# Altium schematic sheet [05]-CT-Sensor.SchDoc
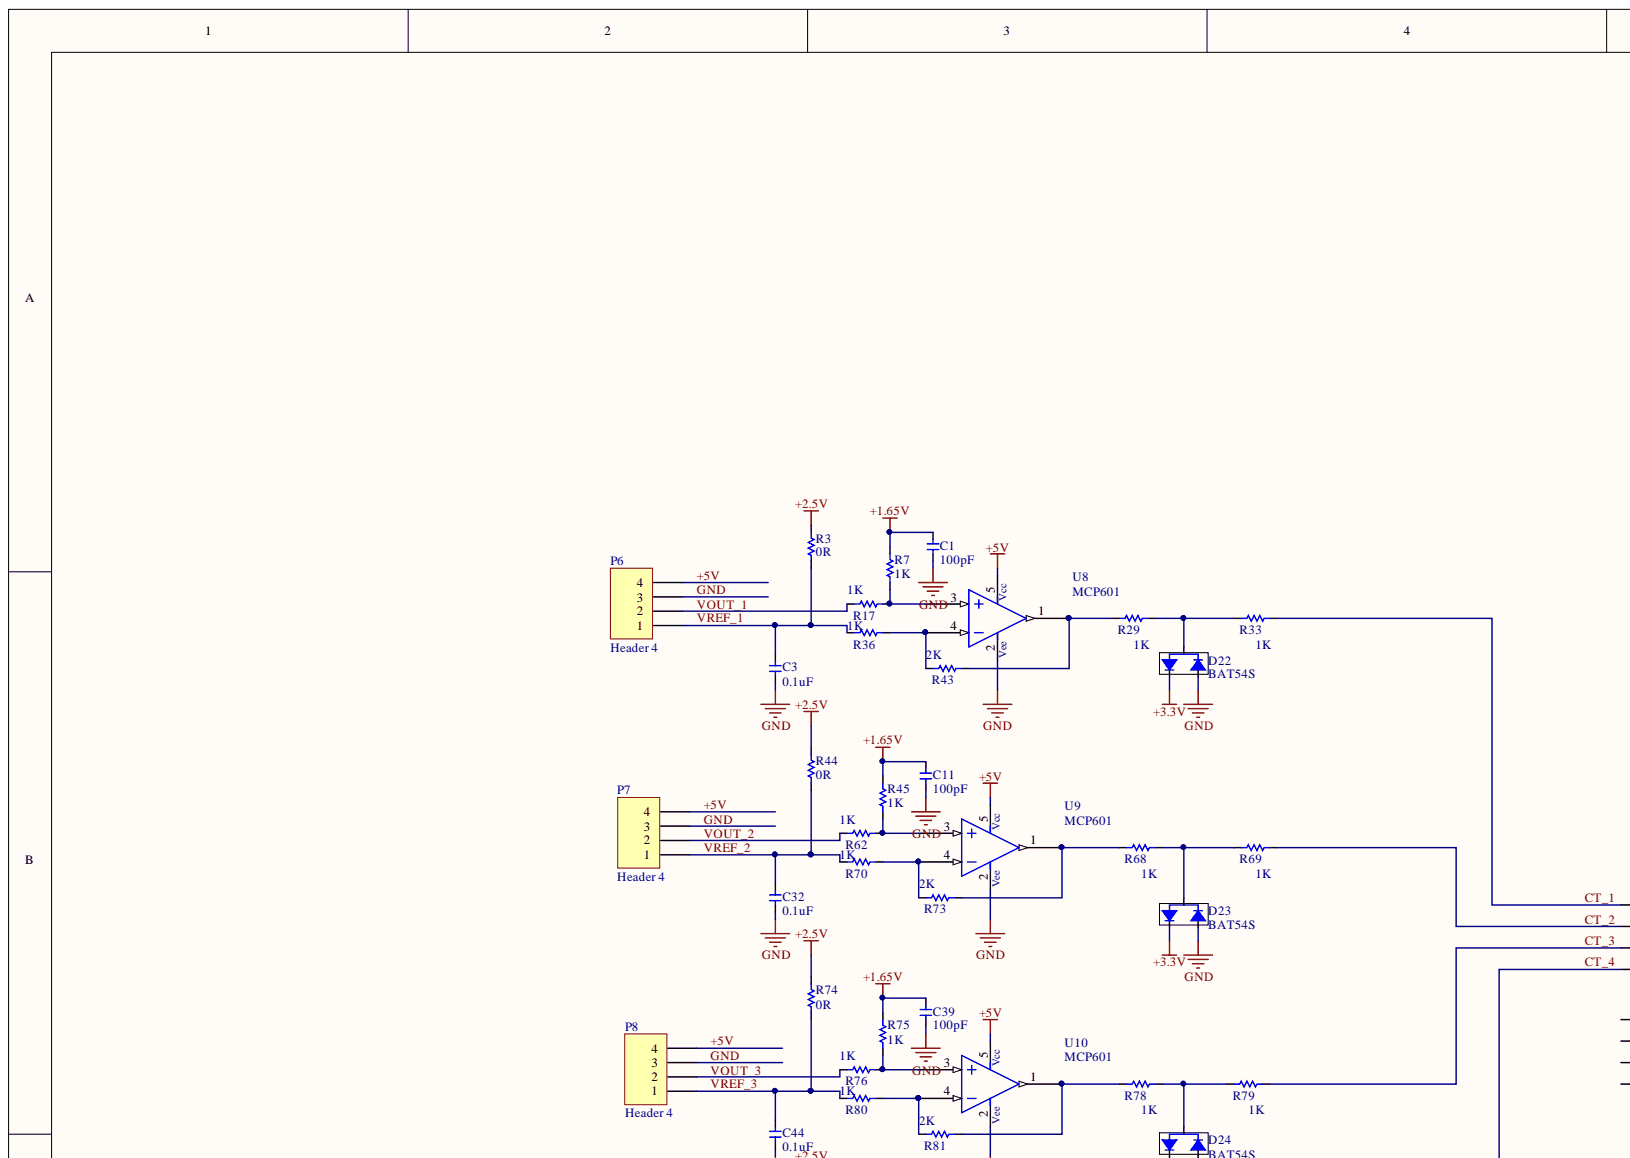
[diagram p0: [05]-CT-Sensor.SchDoc, top left]
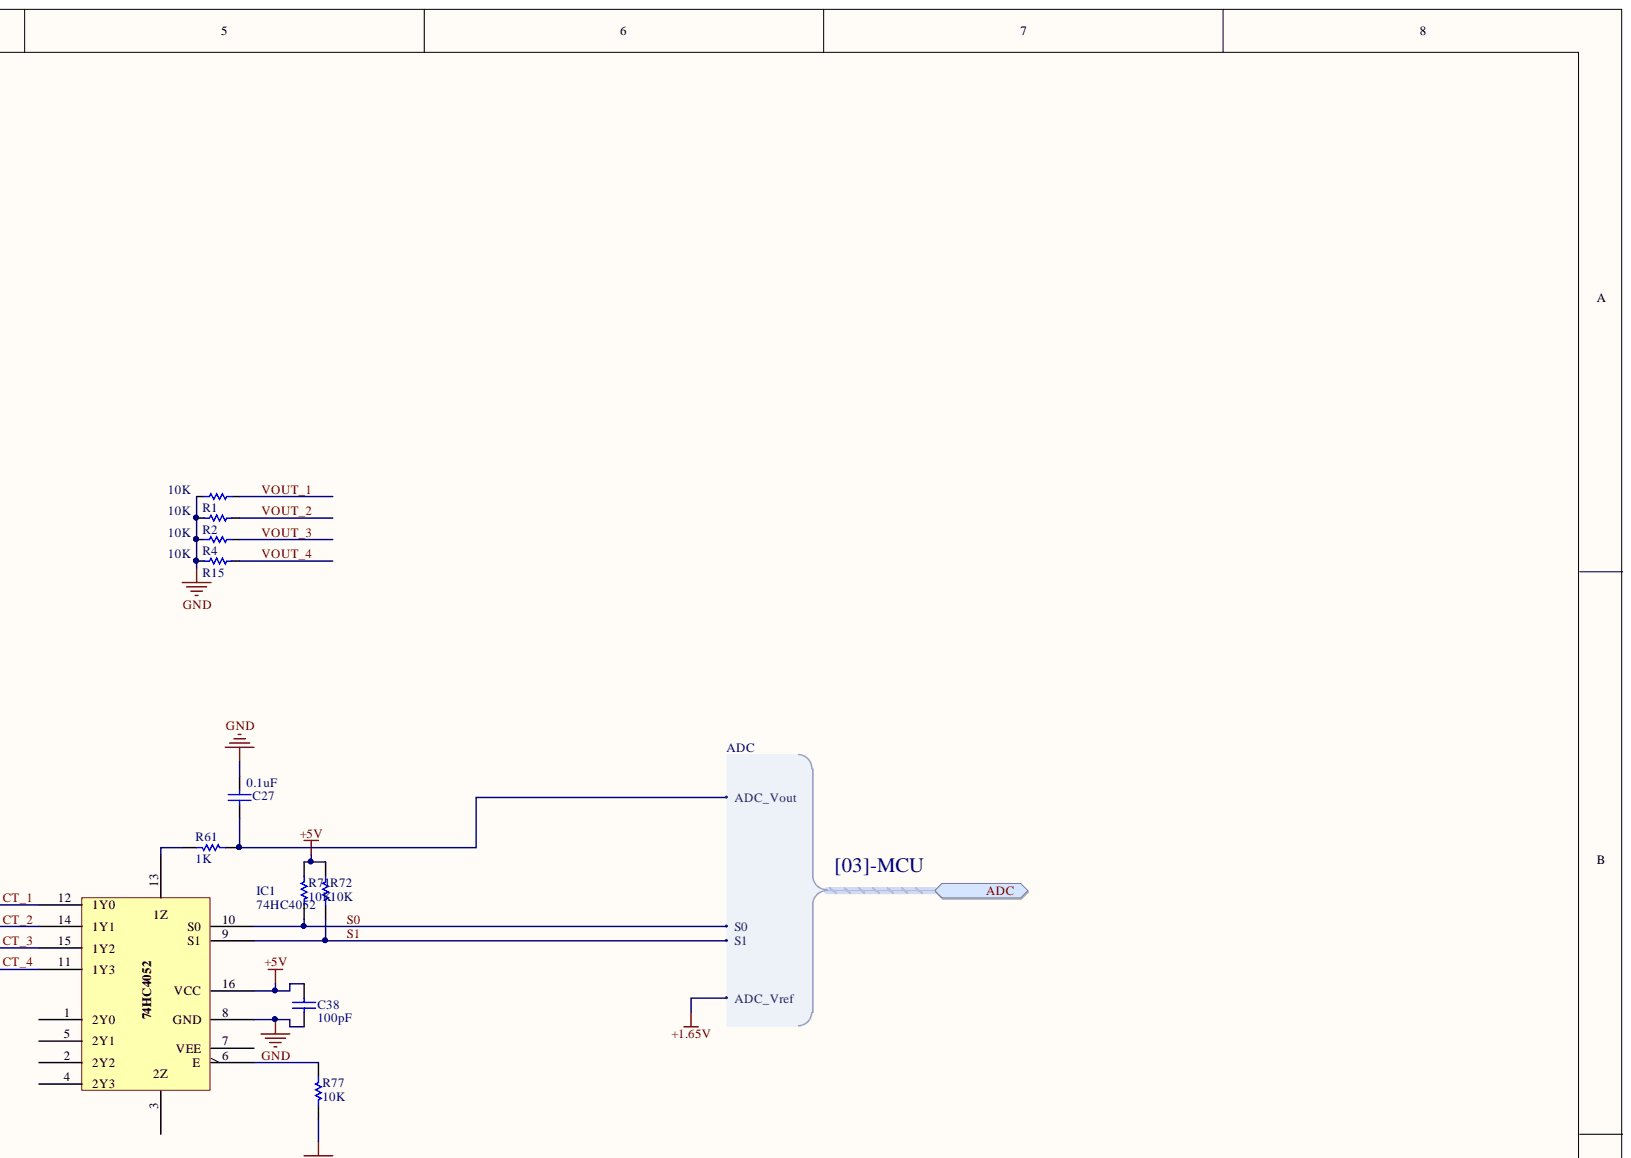
[diagram p1: [05]-CT-Sensor.SchDoc, top right]
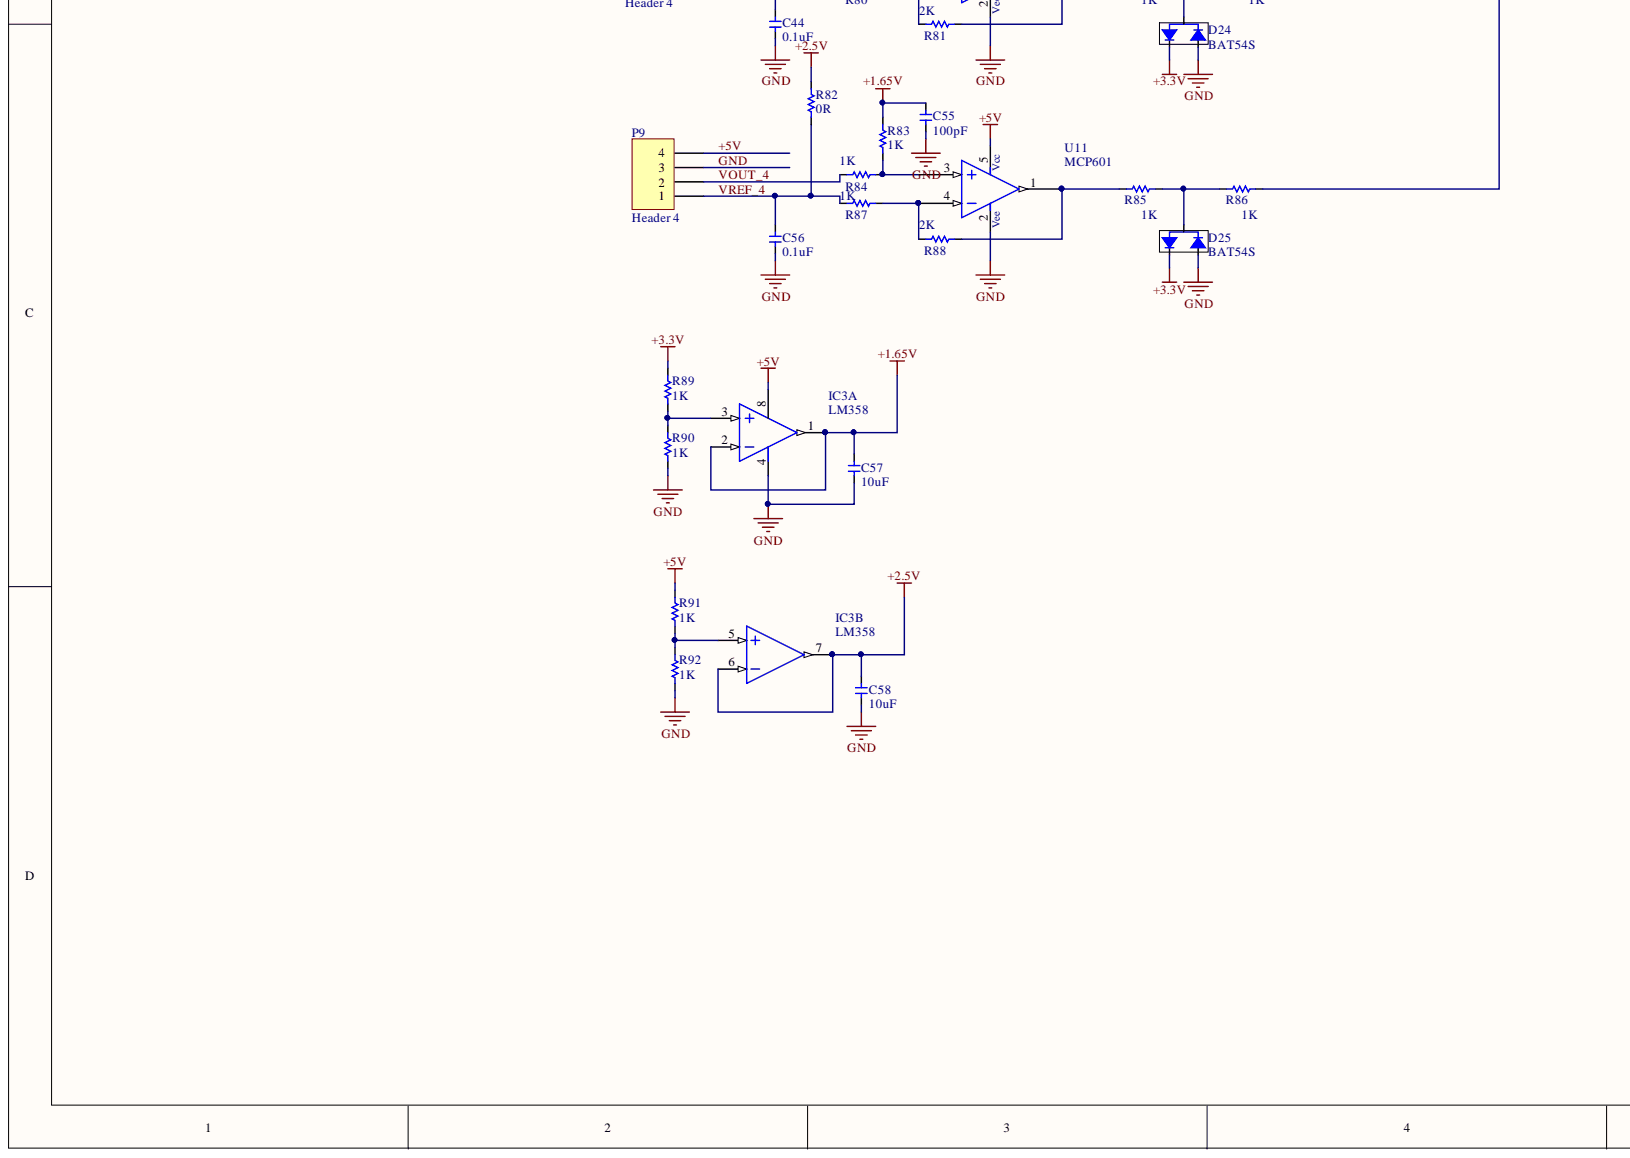
[diagram p2: [05]-CT-Sensor.SchDoc, bottom left]
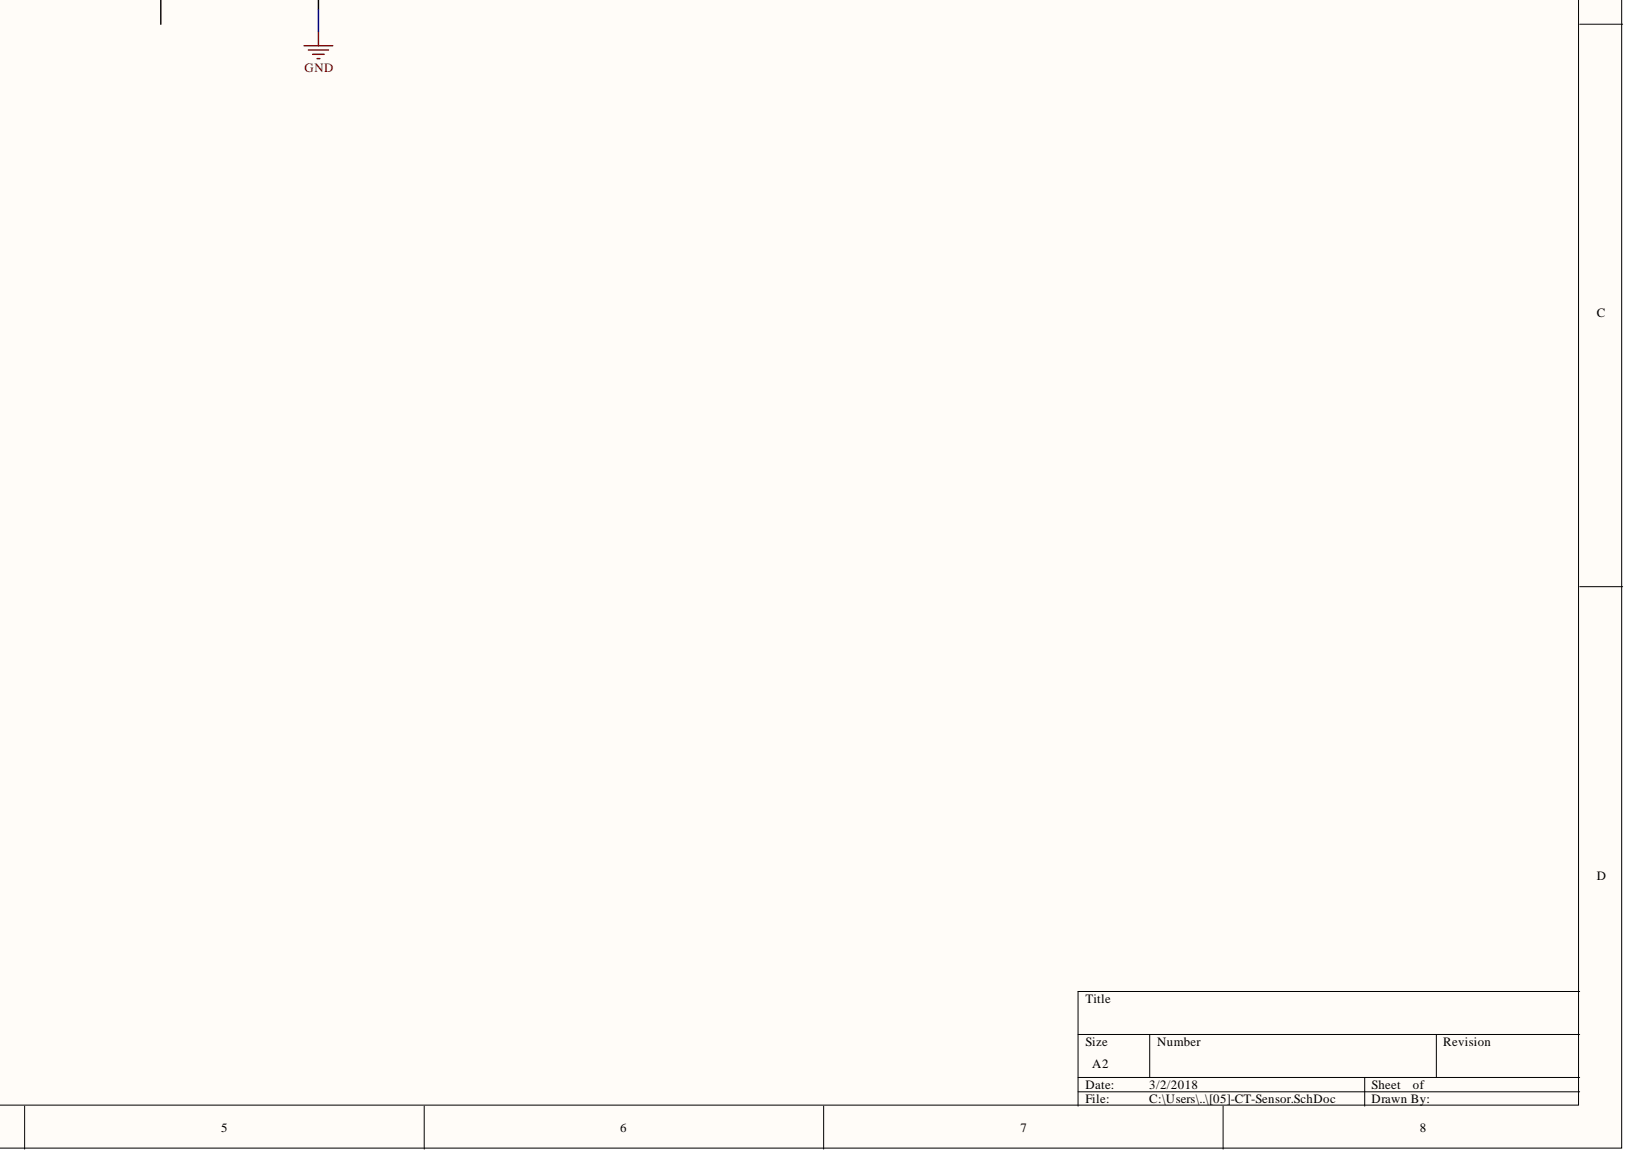
[diagram p3: [05]-CT-Sensor.SchDoc, bottom right]

## Parts
C1  100pF  [cap-0603]
C3  0.1uF  [cap-0603]
C11  100pF  [cap-0603]
C27  0.1uF  [cap-0603]
C32  0.1uF  [cap-0603]
C38  value 100pF  [cap-0603]  (hidden comment: Cap-0805)
C39  100pF  [cap-0603]
C44  0.1uF  [cap-0603]
C55  100pF  [cap-0603]
C56  0.1uF  [cap-0603]
C57  10uF  [cap-0805]
C58  10uF  [cap-0805]
D22  BAT54S  [SOT-23B_L]
D23  BAT54S  [SOT-23B_L]
D24  BAT54S  [SOT-23B_L]
D25  BAT54S  [SOT-23B_L]
IC1  74HC4052  [SOIC127P600X175-16N]
IC3  LM358  [SO8]  (parts A,B)
P6  Header 4  [TBlock4-5.08 ST]
P7  Header 4  [TBlock4-5.08 ST]
P8  Header 4  [TBlock4-5.08 ST]
P9  Header 4  [TBlock4-5.08 ST]
R1  10K  [res-0603]
R2  10K  [res-0603]
R3  0R  [res-0603]
R4  10K  [res-0603]
R7  1K  [res-0603]
R15  10K  [res-0603]
R17  1K  [res-0603]
R29  1K  [res-0603]
R33  1K  [res-0603]
R36  1K  [res-0603]
R43  2K  [res-0603]
R44  0R  [res-0603]
R45  1K  [res-0603]
R61  value 1K  [res-0603]  (hidden comment: Res-0603)
R62  1K  [res-0603]
R68  1K  [res-0603]
R69  1K  [res-0603]
R70  1K  [res-0603]
R71  value 10K  [res-0603]  (hidden comment: Res-0603)
R72  value 10K  [res-0603]  (hidden comment: Res-0603)
R73  2K  [res-0603]
R74  0R  [res-0603]
R75  1K  [res-0603]
R76  1K  [res-0603]
R77  value 10K  [res-0603]  (hidden comment: Res-0603)
R78  1K  [res-0603]
R79  1K  [res-0603]
R80  1K  [res-0603]
R81  2K  [res-0603]
R82  0R  [res-0603]
R83  1K  [res-0603]
R84  1K  [res-0603]
R85  1K  [res-0603]
R86  1K  [res-0603]
R87  1K  [res-0603]
R88  2K  [res-0603]
R89  1K  [res-0603]
R90  1K  [res-0603]
R91  1K  [res-0603]
R92  1K  [res-0603]
U8  MCP601  [RT9193]
U9  MCP601  [RT9193]
U10  MCP601  [RT9193]
U11  MCP601  [RT9193]

## Ports
ADC, ADC.ADC_VOUT, ADC.ADC_VREF, ADC.S0, ADC.S1

## Nets
+2.5V: C58.2 IC3.6 IC3.7 R3.2 R44.2 R74.2 R82.2
+3.3V: D22.2 D23.2 D24.2 D25.2 R89.2
+1.65V: C1.2 C11.2 C39.2 C55.2 C57.2 IC3.1 IC3.2 R7.2 R45.2 R75.2 R83.2 ; port ADC
+5V: C38.2 IC1.16(VCC) IC3.8 P6.4 P7.4 P8.4 P9.4 R71.2 R72.2 R91.2 U8.5 U9.5 U10.5 U11.5
ADC: C27.1 R61.1 ; port ADC
CT_1: IC1.12(1Y0) R33.2
CT_2: IC1.14(1Y1) R69.2
CT_3: IC1.15(1Y2) R79.2
CT_4: IC1.11(1Y3) R86.2
GND: C1.1 C3.1 C11.1 C27.2 C32.1 C38.1 C39.1 C44.1 C55.1 C56.1 C57.1 C58.1 D22.1 D23.1 D24.1 D25.1 IC1.8(GND) IC3.4 P6.3 P7.3 P8.3 P9.3 R1.2 R2.2 R4.2 R15.2 R77.1 R90.1 R92.1 U8.2 U9.2 U10.2 U11.2
S0: IC1.10(S0) R71.1 ; port ADC
S1: IC1.9(S1) R72.1 ; port ADC
VOUT_1: P6.2 R1.1 R17.1
VOUT_2: P7.2 R2.1 R62.1
VOUT_3: P8.2 R4.1 R76.1
VOUT_4: P9.2 R15.1 R84.1
VREF_1: C3.2 P6.1 R3.1 R36.1
VREF_2: C32.2 P7.1 R44.1 R70.1
VREF_3: C44.2 P8.1 R74.1 R80.1
VREF_4: C56.2 P9.1 R82.1 R87.1
NetD22_3: D22.3 R29.2 R33.1
NetD23_3: D23.3 R68.2 R69.1
NetD24_3: D24.3 R78.2 R79.1
NetD25_3: D25.3 R85.2 R86.1
NetIC1_6: IC1.6(E) R77.2
NetIC1_13: IC1.13(1Z) R61.2
NetIC3_3: IC3.3 R89.1 R90.2
NetIC3_5: IC3.5 R91.1 R92.2
NetR7_1: R7.1 R17.2 U8.3
NetR29_1: R29.1 R43.2 U8.1
NetR36_2: R36.2 R43.1 U8.4
NetR45_1: R45.1 R62.2 U9.3
NetR68_1: R68.1 R73.2 U9.1
NetR70_2: R70.2 R73.1 U9.4
NetR75_1: R75.1 R76.2 U10.3
NetR78_1: R78.1 R81.2 U10.1
NetR80_2: R80.2 R81.1 U10.4
NetR83_1: R83.1 R84.2 U11.3
NetR85_1: R85.1 R88.2 U11.1
NetR87_2: R87.2 R88.1 U11.4

Not connected: IC1 1(2Y0) 2(2Y2) 3(2Z) 4(2Y3) 5(2Y1) 7(VEE)

## Library data of the parts
C1 C3 C11 C27 C32 C39 C44 C55 C56 = Cap-0603: Capacitor (Semiconductor SIM Model); Component Kind: Standard; LatestRevisionDate: 29-May-2009; LatestRevisionNote: IPC-7351 Footprint Added.; PackageDescription: Chip Capacitor; PackageReference: C1206; Published: 8-Jun-2000; Library Name: THUVIENTUTAO.lib; Publisher: Altium Limited
C38 C57 C58 = Cap-0805: Capacitor (Semiconductor SIM Model); Component Kind: Standard; LatestRevisionDate: 29-May-2009; LatestRevisionNote: IPC-7351 Footprint Added.; PackageDescription: Chip Capacitor; PackageReference: C1206; Published: 8-Jun-2000; Publisher: Altium Limited
  C38: Library Name: Devices.SchLib
  C57 C58: Library Name: THUVIENTUTAO.lib
D22-D25 = Diode BAT54S: Component Kind: Standard; Library Name: THUVIENTUTAO.lib
IC3 = LM358: Low-Power Dual Operational Amplifier; Component Kind: Standard; Value: LM358; Library Name: THUVIENTUTAO.lib
P6-P9 = Header 4: Header, 4-Pin; Component Kind: Standard; LatestRevisionDate: 17-Jul-2002; LatestRevisionNote: Re-released for DXP Platform.; Library Name: THUVIENTUTAO.lib; Publisher: Altium Limited
R1-R4 R7 R15 R17 R29 R33 R36 R43-R45 R61 R62 R68-R92 = Res-0603: Resistor; Component Kind: Standard; LatestRevisionDate: 17-Jul-2002; LatestRevisionNote: Re-released for DXP Platform.; PackageDescription: Axial Device, Thru-Hole; 2 Leads; 0.3 in Pin Spacing; PackageReference: AXIAL-0.3; Published: 8-Jun-2000; Publisher: Altium Limited
  R77: Library Name: Devices.SchLib
  R1-R4 R7 R15 R17 R29 R33 R36 R43-R45 R61 R62 R68-R76 R78-R92: Library Name: THUVIENTUTAO.lib
IC1 = 74HC4052: Component Kind: Standard; Library Name: THUVIENTUTAO.lib
U8-U11 = MCP601: Component Kind: Standard; Library Name: THUVIENTUTAO.lib
